# Altium schematic sheet IO.SchDoc(IO)
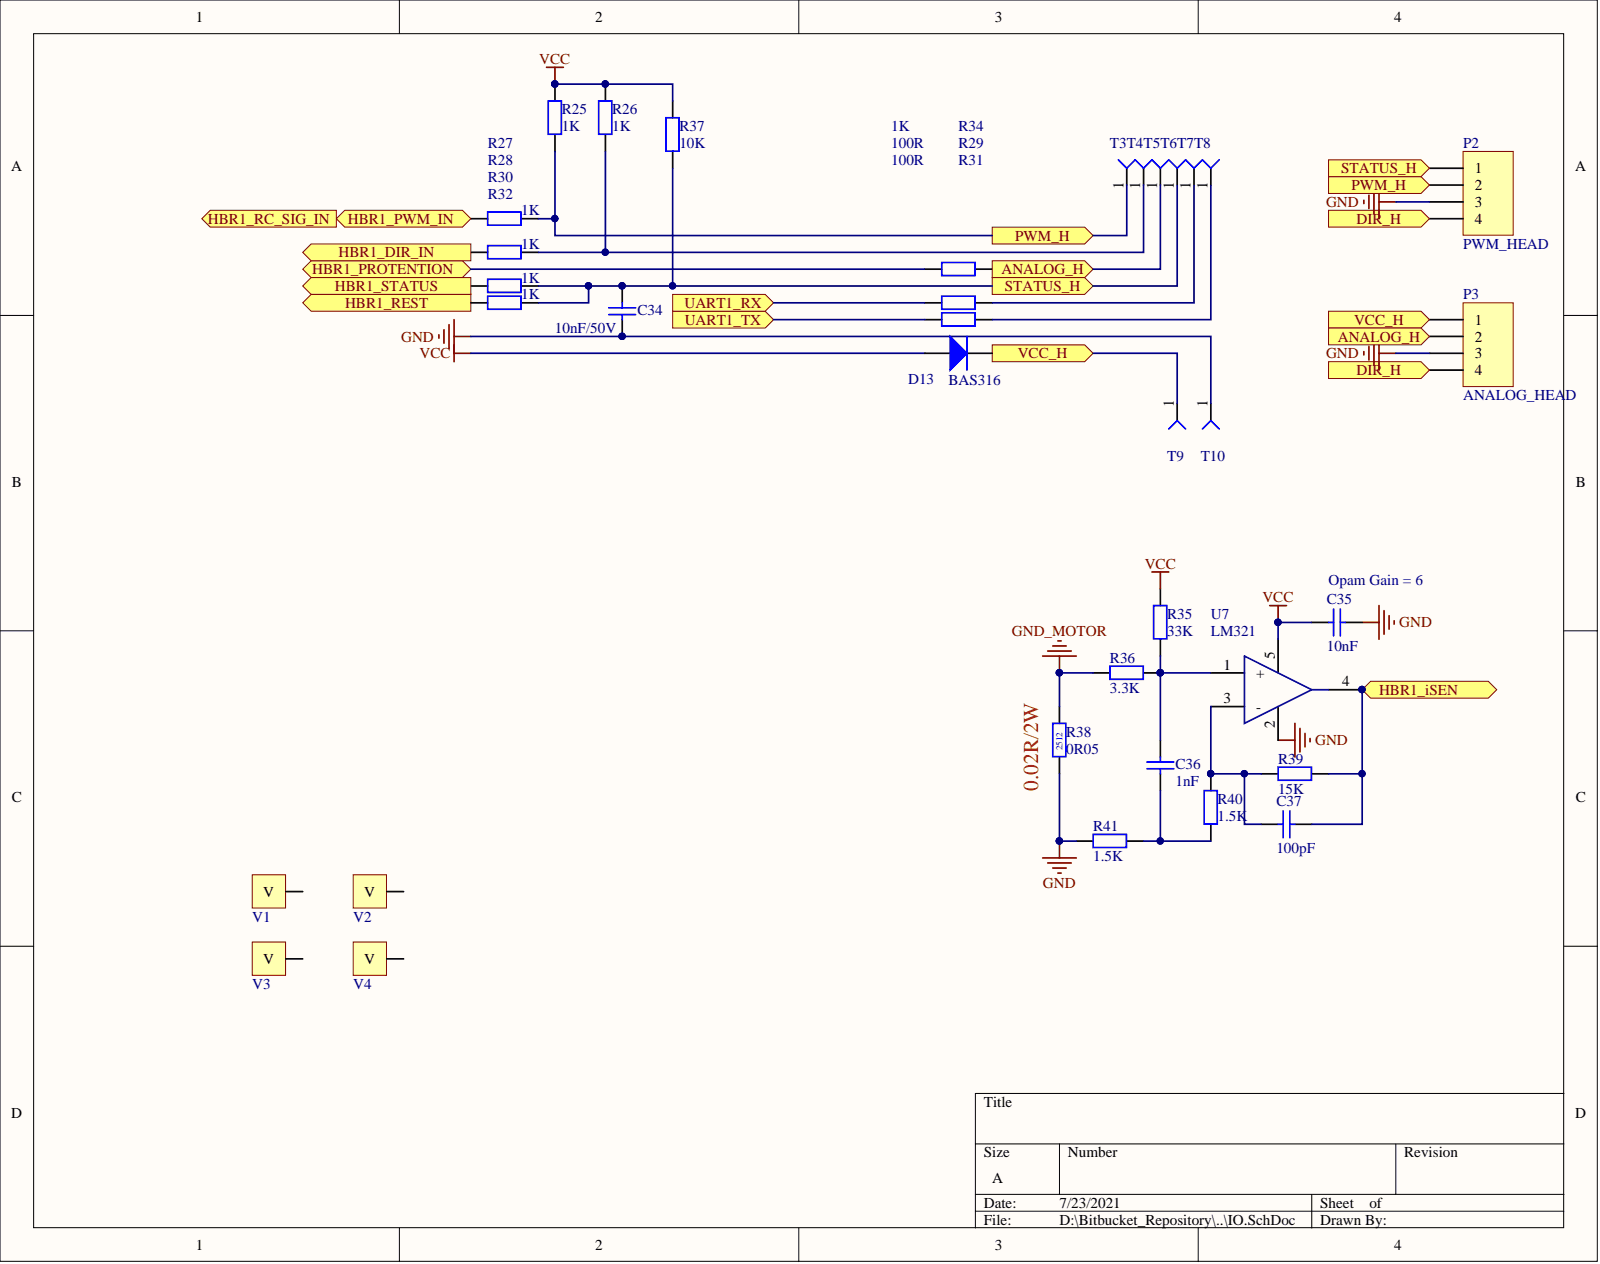
[diagram p0: IO.SchDoc]

## Parts
C34  value 10nF/50V  [C0603]  (hidden comment: Ceramic Cap 0603 0.01uF 50V 10% X7R)
C35  value 10nF  [C0603]  (hidden comment: Ceramic Cap 0603 0.01uF 50V 10% X7R)
C36  value 1nF  [C0603]  (hidden comment: Ceramic Cap 0603 1nF 50V 1% NP0)
C37  value 100pF  [C0603]  (hidden comment: Ceramic Cap 0603 100pF 50V 5% NP0)
D13  BAS316  [SOD-323]
P2  PWM_HEAD  [XH_SMD_ 4Pin]
P3  ANALOG_HEAD  [XH_SMD_ 4Pin]
R25  value 1K  [R0603]  (hidden comment: RES 1K 1% 1/10W 0603)
R26  value 1K  [R0603]  (hidden comment: RES 1K 1% 1/10W 0603)
R27  value 1K  [R0603]  (hidden comment: RES 1K 1% 1/10W 0603)
R28  value 1K  [R0603]  (hidden comment: RES 1K 1% 1/10W 0603)
R29  value 100R  [R0603]  (hidden comment: RES 100R 5% 1/10W 0603)
R30  value 1K  [R0603]  (hidden comment: RES 1K 1% 1/10W 0603)
R31  value 100R  [R0603]  (hidden comment: RES 100R 5% 1/10W 0603)
R32  value 1K  [R0603]  (hidden comment: RES 1K 1% 1/10W 0603)
R34  value 1K  [R0603]  (hidden comment: RES 1K 1% 1/10W 0603)
R35  value 33K  [R0603]  (hidden comment: RES SMD 33K OHM 5% 1/10W 0603)
R36  value 3.3K  [R0603]  (hidden comment: RES 3.3K OHM 1/10W 5% 0603 SMD)
R37  value 10K  [R0603]  (hidden comment: RES 10K 1% 1/10W 0603)
R38  value 0R05  [R2725]  (hidden comment: RES 0.05 OHM 5% 1W 2512)
R39  value 15K  [R0603]  (hidden comment: RES 15K 5% 1/10W 0603)
R40  value 1.5K  [R0603]  (hidden comment: RES 1.5K 5% 1/10W 0603)
R41  value 1.5K  [R0603]  (hidden comment: RES 1.5K 5% 1/10W 0603)
T3  Test point  [Test point 1- 1.5 mm]  (value not drawn)
T4  Test point  [Test point 1- 1.5 mm]  (value not drawn)
T5  Test point  [Test point 1- 1.5 mm]  (value not drawn)
T6  Test point  [Test point 1- 1.5 mm]  (value not drawn)
T7  Test point  [Test point 1- 1.5 mm]  (value not drawn)
T8  Test point  [Test point 1- 1.5 mm]  (value not drawn)
T9  Test point  [Test point 1- 1.5 mm]  (value not drawn)
T10  Test point  [Test point 1- 1.5 mm]  (value not drawn)
U7  LM321  [SOT-23-5]
V1  Vit  [Drill2.5-D5]  (value not drawn)
V2  Vit  [Drill2.5-D5]  (value not drawn)
V3  Vit  [Drill2.5-D5]  (value not drawn)
V4  Vit  [Drill2.5-D5]  (value not drawn)

## Ports
ANALOG_H, DIR_H, HBR1_DIR_IN, HBR1_ISEN, HBR1_PROTENTION, HBR1_PWM_IN, HBR1_RC_SIG_IN, HBR1_REST, HBR1_STATUS, PWM_H, STATUS_H, UART1_RX, UART1_TX, VCC_H

## Nets
GND: C34.1 C35.2 P2.3 P3.3 R38.1 R41.2 T10.1 U7.2
GND_MOTOR: R36.1 R38.2
VCC: C35.1 D13.1 R25.2 R26.2 R35.2 R37.2 U7.5
ANALOG_H: P3.2 R34.1 T5.1 ; port ANALOG_H
DIR_H: P2.4 P3.4 ; port DIR_H
HBR1_DIR_IN: R28.1 ; port HBR1_DIR_IN
HBR1_PROTENTION: R34.2 ; port HBR1_PROTENTION
HBR1_PWM_IN: R27.1 ; port HBR1_PWM_IN, port HBR1_RC_SIG_IN
HBR1_REST: R32.1 ; port HBR1_REST
HBR1_STATUS: R30.1 ; port HBR1_STATUS
PWM_H: P2.2 R25.1 R27.2 T3.1 ; port PWM_H
STATUS_H: C34.2 P2.1 R30.2 R32.2 R37.1 T6.1 ; port STATUS_H
UART1_RX: R29.1 ; port UART1_RX
UART1_TX: R31.1 ; port UART1_TX
VCC_H: D13.2 P3.1 T9.1 ; port VCC_H
NetC36_1: C36.1 R40.2 R41.1
NetC36_2: C36.2 R35.1 R36.2 U7.1(+)
NetC37_1: C37.1 R39.1 R40.1 U7.3(-)
NetC37_2: C37.2 R39.2 U7.4 ; port HBR1_ISEN
NetR26_1: R26.1 R28.2 T4.1
NetR29_2: R29.2 T7.1
NetR31_2: R31.2 T8.1

Not connected: V1 1; V2 1; V3 1; V4 1

## Library data of the parts
C34 C35 = GRM188R71H103KA01: Murata General Purpose Ceramic Cap 0603 0.01uF 50V 10% X7R; Component Kind: Standard; Library Name: capacitor.SchLib; Signal Integrity: Cap; Simulation: CAP; Supplier 1: Digi-Key; Supplier Part Number 1: 490-1512-1-ND; Supplier Part Number 2: FL1129
C36 = GRM1885C1H102FA01: Murata General Purpose Ceramic Cap 0603 1nF 50V 1% NP0; Component Kind: Standard; Library Name: capacitor.SchLib; Signal Integrity: Cap; Simulation: CAP; Supplier 1: Digi-Key; Supplier Part Number 1: 490-6377-6-ND; Supplier Part Number 2: FL1116
C37 = GRM1885C1H101JA01: Murata General Purpose Ceramic Cap 0603 100pF 50V 5% NP0; Component Kind: Standard; Library Name: capacitor.SchLib; Signal Integrity: Cap; Simulation: CAP; Supplier 1: Digi-Key; Supplier Part Number 1: 490-1427-1-ND
D13 = BAS316: Fast Switching Diode 100V 0.25A SOD-323; Component Kind: Standard; Library Name: diode.SchLib; Supplier 1: Digi-Key; Supplier Part Number 1: 568-5003-1-ND; Supplier Part Number 2: FL1504; Value: BAS316
P2 P3 = Header 4: Header, 4-Pin; Component Kind: Standard; LatestRevisionDate: 17-Jul-2002; LatestRevisionNote: Re-released for DXP Platform.; Library Name: Miscellaneous Connectors.IntLib; Signal Integrity: Connector; Publisher: Altium Limited
R25-R28 R30 R32 R34 = RC0603FR-071KL: Yageo RES 1K 1% 1/10W 0603; Component Kind: Standard; Library Name: resistor.SchLib; Signal Integrity: Res; Simulation: RESISTOR; Supplier 1: Digi-Key; Supplier Part Number 1: 311-1.00KHRCT-ND
R29 R31 = RC0603JR-07100RL: Yageo RES 100R 5% 1/10W 0603; Component Kind: Standard; Library Name: resistor.SchLib; Signal Integrity: Res; Simulation: RESISTOR; Supplier 1: Digi-Key; Supplier Part Number 1: 311-100GRCT-ND; Supplier Part Number 2: FL2438
R35 = RC0603JR-0733KL: Yageo RES 33k 5% 1/10W 0603; Component Kind: Standard; Library Name: resistor.SchLib; Signal Integrity: Res; Simulation: RESISTOR; Supplier 1: Digi-Key; Supplier Part Number 1: 311-33KGRCT-ND; Supplier Part Number 2: FL2430
R36 = RC0603JR-073K3L: Yageo RES 3.3K OHM 1/10W 5% 0603 SMD; Component Kind: Standard; Library Name: resistor.SchLib; Signal Integrity: Res; Simulation: RESISTOR; Supplier 1: Digi-Key; Supplier Part Number 1: 311-3.3KGRCT-ND; Supplier Part Number 2: FL2474
R37 = RC0603FR-0710KL: Yageo RES 10K 1% 1/10W 0603; Component Kind: Standard; Library Name: resistor.SchLib; Signal Integrity: Res; Simulation: RESISTOR; Supplier 1: Digi-Key; Supplier Part Number 1: 311-10.0KHRCT-ND
R38 = RL2512JK-070R05L: 50 mOhms ±5% 1W Chip Resistor 2512 (6432 Metric) Automotive AEC-Q200, Current Sense, Moisture Resistant Thick Film; Component Kind: Standard; Library Name: resistor.SchLib; Signal Integrity: Res; Simulation: RESISTOR; Supplier 2: Allied; Supplier Part Number 2: Flxxxx
R39 = RMCF0603JT15K0: Yageo RES 15K 5% 1/10W 0603; Component Kind: Standard; Library Name: resistor.SchLib; Signal Integrity: Res; Simulation: RESISTOR; Supplier 1: Digi-Key; Supplier Part Number 1: 311-200KGRCT-ND
R40 R41 = RC0603JR-071K5L: Yageo RES 1.5K 5% 1/10W 0603; Component Kind: Standard; Library Name: resistor.SchLib; Signal Integrity: Res; Simulation: RESISTOR; Supplier 1: Digi-Key; Supplier Part Number 1: 311-1.5KGRCT-ND
T3-T10 = TEST_POINT: Component Kind: Standard; Library Name: lib.SchLib
U7 = LM321: IC OPAMP GP 1MHZ SOT23-5; Component Kind: Standard; Library Name: opamp.SchLib; Supplier 1: Digi-Key; Supplier Part Number 1: LM321MFX/NOPBDKR-ND; value: LM321
V1-V4 = Vit: Component Kind: Standard; Library Name: connector.SchLib
